# Altium schematic sheet Sheet1.SchDoc(Sheet1)
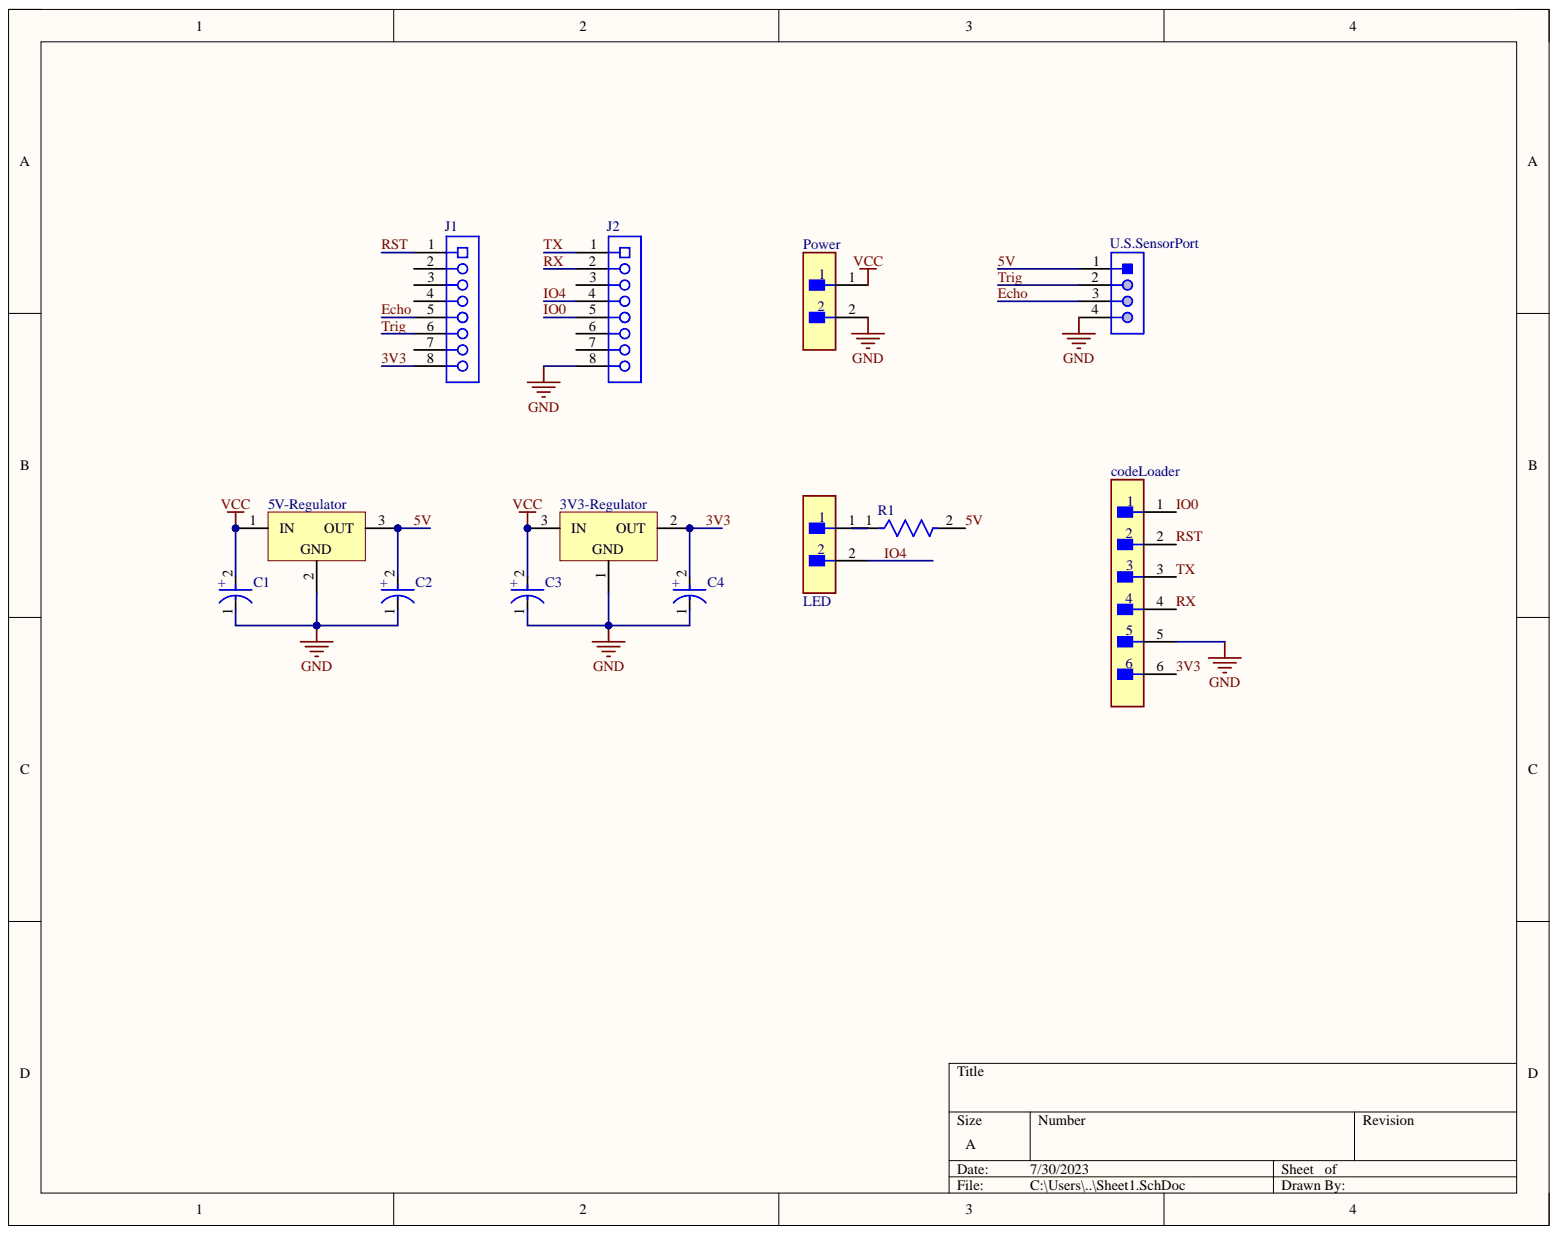
[diagram p0: Sheet1.SchDoc]

## Parts
3V3-Regulator  LM1117T-3.3/NOPB  [NDE0003A]  (value not drawn)
5V-Regulator  L7805CV  [TO220]  (value not drawn)
C1  EEUHD1H330  [FP-RAD-TH-D_5_0_5-L_11_1-MFG]  (value not drawn)
C2  EEUHD1H330  [FP-RAD-TH-D_5_0_5-L_11_1-MFG]  (value not drawn)
C3  EEUHD1H330  [FP-RAD-TH-D_5_0_5-L_11_1-MFG]  (value not drawn)
C4  EEUHD1H330  [FP-RAD-TH-D_5_0_5-L_11_1-MFG]  (value not drawn)
codeLoader  22-28-4063  [FP-22-28-4063-MFG]  (value not drawn)
J1  PPPC081LFBN-RC  [SLIN-PPPC081LFBN-RC_V]  (value not drawn)
J2  PPPC081LFBN-RC  [SLIN-PPPC081LFBN-RC_V]  (value not drawn)
LED  M20-9990246  [FP-M20-9990246-MFG]  (value not drawn)
Power  M20-9990246  [FP-M20-9990246-MFG]  (value not drawn)
R1  MRS25000C9530FCT00  [FP-MRS25-MFG]  (value not drawn)
U.S.SensorPort  61300411121  [61300411121]  (value not drawn)

## Nets
GND: 3V3-Regulator.1 5V-Regulator.2 C1.1 C2.1 C3.1 C4.1 codeLoader.5 J2.8 Power.2 U.S.SensorPort.4
VCC: 3V3-Regulator.3 5V-Regulator.1 C1.2 C3.2 Power.1
3V3: 3V3-Regulator.2 C4.2 codeLoader.6 J1.8
5V: 5V-Regulator.3 C2.2 R1.2 U.S.SensorPort.1
Echo: J1.5 U.S.SensorPort.3
IO0: codeLoader.1 J2.5
IO4: J2.4 LED.2
RST: codeLoader.2 J1.1
RX: codeLoader.4 J2.2
Trig: J1.6 U.S.SensorPort.2
TX: codeLoader.3 J2.1
NetLED_1: LED.1 R1.1

Not connected: J1 2 3 4 7; J2 3 6 7

## Library data of the parts
3V3-Regulator = CMP-0062-02494-3: 800mA Low-Dropout Linear Regulator, 3-pin TO-220, Pb-Free; Manufacturer: Texas Instruments; CompType: LDO IC; PackageTopMarking: NSUZXYTTE#*LM1117T*3.3; PowerWise: N; Component Kind: Standard; ComponentLink1Description: Datasheet URL; ComponentLink1URL: http://www.ti.com/general/docs/lit/getliterature.tsp?genericPartNumber=LM1117-N&fileType=pdf; Library Name: Altium Content Vault; PackageDescription: TO-220, Molded, 3 Lead, Straight; PackageReference: NDE0003A; PinCount: 3; RoHS: Y
5V-Regulator = CMP-0244-00483-1: Positive Voltage Regulator, 5V, 3-Pin TO-220; Component Kind: Standard; ComponentLink1Description: Manufacturer URL; ComponentLink1URL: http://www.st.com; ComponentLink2Description: Datasheet; ComponentLink2URL: http://www.st.com/internet/com/TECHNICAL_RESOURCES/TECHNICAL_LITERATURE/DATASHEET/CD00000444.pdf; ComponentLink3Description: Package Specification; ComponentLink3URL: http://www.st.com/internet/com/TECHNICAL_RESOURCES/TECHNICAL_LITERATURE/PACKAGE_INFORMATION/CD00004649.pdf; DatasheetVersion: 16/11/2011; Library Name: Altium Content Vault; PackageDescription: TO, Thru-Hole, Vertical, Heatsink Mounted; 3 In-Line Leads; Pitch 2.54 mm; PackageReference: TO220; PackageVersion: ver3.0
C1-C4 = CMP-05427-001662-1: Aluminum Electrolytic Capacitors (Radial Lead Type) AEC-Q200 Qualified 33uF ±20% 50V; Lead Diameter: 0.5mm; Lead/Base Style: Straight; Life (Hours): 1000Hour; Polarity: Polar; Ripple Current: 90mA; Capacitance: 33uF; Case/Package: Radial; Component Kind: Standard; Construction: RADIAL; Diameter: 5mm; Height: 11mm; Height - Seated (Max): 0.492inch; Lead Pitch: 2.5mm; Length: 11mm; Library Name: Altium Content Vault; Max Operating Temperature: 105°C; Min Operating Temperature: -55°C; Mount: Through Hole; Package Quantity: 200; Packaging: Bulk; REACH SVHC: No SVHC; Ripple Current (AC): 90mA; RoHS Compliant: Yes; Series: HD; Termination: Radial; Tolerance: 20%; Voltage: 50V; Voltage Rating: 50V; Voltage Rating (DC): 50V
codeLoader = CMP-04776-000885-1: CONN HEADER VERT 6POS 2.54MM; Connector Type: Male Pin; Contact Resistance: 20mR; Current Rating: 4A; Gender: Male; Glow Wire Compliant: Non-compliant; Max Voltage Rating (AC): 250V; Max Voltage Rating (DC): 250.25kV; Number of Circuits: 6; Color: Black; Component Kind: Standard; Contact Material: Brass; Contact Mating Length: 0.24inch; Contact Plating: Gold; Contact Type: Male Pin; Depth: 2.49mm; Flammability Rating: UL94 V-0; Housing Color: Black; Housing Material: Thermoplastic; Lead Free: Lead Free; Library Name: Altium Content Vault; Max Operating Temperature: 75°C; Min Operating Temperature: 0°C; Mount: Through Hole; Number of Contacts: 6; Number of Positions: 6; Number of Rows: 1; Orientation: Straight; Package Quantity: 1; Packaging: Bulk; Pitch: 2.54mm; Radiation Hardening: No; RoHS Compliant: Yes; Series: KK® 42375; Termination: Solder; Voltage Rating: 250V; Voltage Rating (DC): 250V
J1 J2 = CMP-1770-00002-1: Female Header, Pitch 2.54 mm, 1 x 8 Position, Height 8.5 mm, Tail Length 3.2 mm, -40 to 105 degC, RoHS, Bulk; Case\Package: TO-252-3; Elements: 1; Input Capacitance: 350 pF; Max Power Dissipation: 2.5 W; Rds On Max: 115 mohm; Typical Rise Time: 90 ns; Component Kind: Standard; ComponentLink1Description: Datasheet; ComponentLink1URL: http://sullinscorp.com/catalogs/101_PAGE114-115_.100_FEMALE_HDR.pdf; DatasheetVersion: Jan-15; Height: 2.39 mm; Length: 6.73 mm; Library Name: Altium Content Vault; Max Operating Temperature: 150 degC; Min Operating Temperature: -55 degC; Mount: Surface Mount; Package Quantity: 2500; PackageDescription: Female Header, Pitch 2.54 mm, 1 x 8 Position, Height 8.5 mm, Tail Length 3.2 mm; PackageReference: PPPC081LFBN-RC; Packaging: Tape and Reel; Pins: 3; Radiation Hardening: No; REACH SVHC: No SVHC; RoHSCompliant: true; Width: 6.22 mm
LED Power = CMP-15831-000009-1: CONN HEADER VERT 2POS 2.54MM; Manufacturer: Harwin; Conn Gender: Male; Conn Orientation: Vertical; Current Rating: 3A; Number Of Contacts: 2; Automotive: No; Category: Conn; Component Kind: Standard; ComponentLink2Description: Datasheet; ComponentLink2URL: https://cdn.harwin.com/pdfs/M20-999.pdf; Contact Material: Tin,Nickel; Device Class L1: Connectors; Device Class L2: Headers and Wire Housings; Device Class L3: unset; Digikey Description: CONN HEADER VERT 2POS 2.54MM; Height: 8.99mm; Lead Free: Yes; Library Name: Altium Content Vault; Manufacturer Part Number: M20-9990246; Mouser Description: 2.54mm (0.1") Pitch SIL Vertical PC Tail Pin Header, 6.1mm mating pin height, tin, 2 contacts; Number Of Rows: 1; Octopart: https://octopart.com/m20-9990246-harwin-2590635; Package: M20-9990246; Pitch: 2.54mm; RoHS: TRUE; Temperature: -40°C to +105°C; Temperature Range High: +105°C; Temperature Range Low: -40°C
R1 = CMP-02408-000049-1: RES 953 OHM 0.6W 1% AXIAL; Lead Length: 26mm; Max Power Dissipation: 600mW; Resistance: 953R; Temperature Coefficient: 50ppm/°C; Voltage Rating: 350V; Case/Package: Axial; Component Kind: Standard; Construction: Cylindrical; Contact Plating: Tin; Diameter: 2.5mm; Lead Free: Lead Free; Length: 6.5mm; Library Name: Altium Content Vault; Max Operating Temperature: 155°C; Military Standard: Not; Min Operating Temperature: -55°C; Number of Terminations: 2; Packaging: Tape and Reel; Power Rating: 600mW; Radiation Hardening: No; Resistance Tolerance: 1%; Resistor Type: Metal Film; RoHS Compliant: Yes; Series: MRS25; Technology: THIN FILM; Termination: Axial; Tolerance: 1%; Width: 2.5mm
U.S.SensorPort = CMP-1502-01064-1: THT Vertical Pin Header WR-PHD, Pitch 2.54 mm, Single Row, 4 pins; Manufacturer: Wurth Elektronik; Contact Resistance: 20mOhm; Gender: Male; Pin Number: 4; Pitch: 2.54mm; Rated Current: 3A; Withstanding Voltage: 500VAC; Working Voltage: 250VAC; Component Kind: Standard; Datasheet URL: https://katalog.we-online.de/em/datasheet/6130xx11121.pdf; Library Name: Altium Content Vault; Manufacturer URL: http://www.we-online.de; Mounting Technology: THT; Operating Temperature: -40to105degC; Orientation: Vertical; Package Reference: 61300411121; Packaging: Bag; Part Number: 61300411121; Row Number: Single; Publisher: WurthElektronik
